# Altium schematic sheet AN-DGX - PMOD Connectors.SchDoc("AN-DGX - PMOD Connectors")
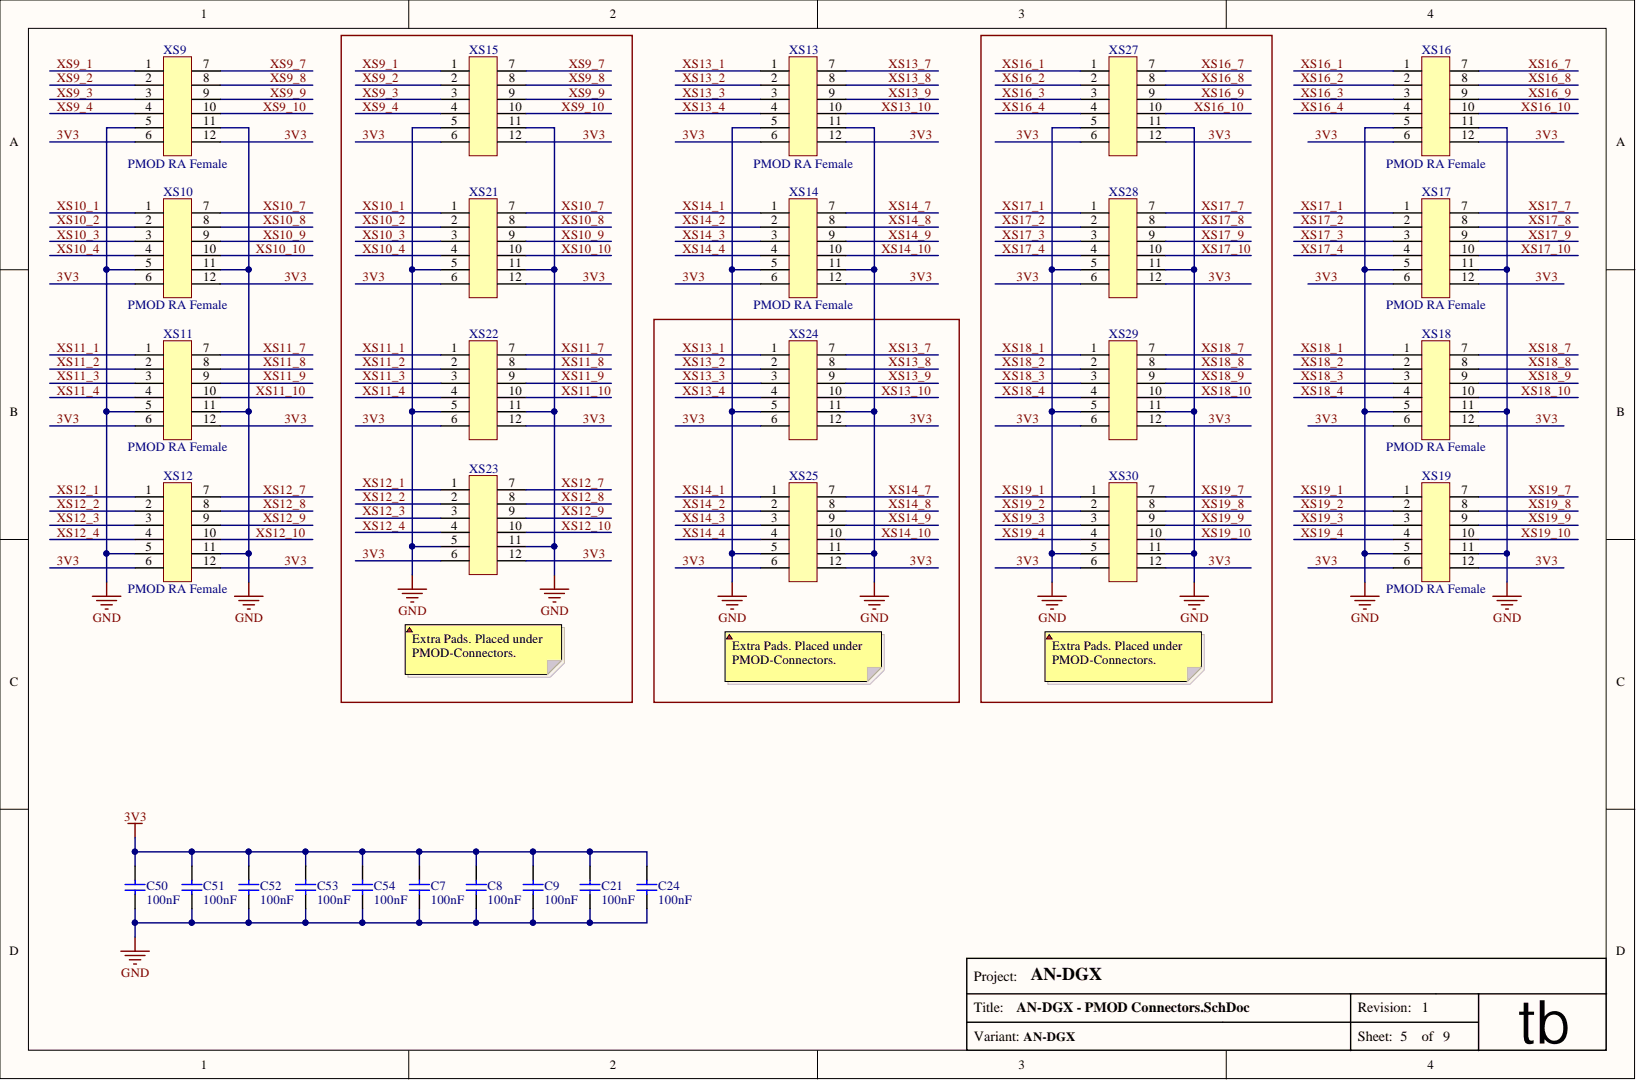
[diagram p0: AN-DGX - PMOD Connectors.SchDoc]

## Parts
C7  value 100nF  [C0603]  (hidden comment: CAP)
C8  value 100nF  [C0603]  (hidden comment: CAP)
C9  value 100nF  [C0603]  (hidden comment: CAP)
C21  value 100nF  [C0603]  (hidden comment: CAP)
C24  value 100nF  [C0603]  (hidden comment: CAP)
C50  value 100nF  [C0603]  (hidden comment: CAP)
C51  value 100nF  [C0603]  (hidden comment: CAP)
C52  value 100nF  [C0603]  (hidden comment: CAP)
C53  value 100nF  [C0603]  (hidden comment: CAP)
C54  value 100nF  [C0603]  (hidden comment: CAP)
XS9  value PMOD RA Female  [CONN_2DG_H_F]  (hidden comment: DG2_connector_horizontal_f)
XS10  value PMOD RA Female  [CONN_2DG_H_F]  (hidden comment: DG2_connector_horizontal_f)
XS11  value PMOD RA Female  [CONN_2DG_H_F]  (hidden comment: DG2_connector_horizontal_f)
XS12  value PMOD RA Female  [CONN_2DG_H_F]  (hidden comment: DG2_connector_horizontal_f)
XS13  value PMOD RA Female  [CONN_2DG_H_F]  (hidden comment: DG2_connector_horizontal_f)
XS14  value PMOD RA Female  [CONN_2DG_H_F]  (hidden comment: DG2_connector_horizontal_f)
XS15  Pads  [Conn_2DG_Pads]  (value not drawn)  (no BOM)
XS16  value PMOD RA Female  [CONN_2DG_H_F]  (hidden comment: DG2_connector_horizontal_f)
XS17  value PMOD RA Female  [CONN_2DG_H_F]  (hidden comment: DG2_connector_horizontal_f)
XS18  value PMOD RA Female  [CONN_2DG_H_F]  (hidden comment: DG2_connector_horizontal_f)
XS19  value PMOD RA Female  [CONN_2DG_H_F]  (hidden comment: DG2_connector_horizontal_f)
XS21  Pads  [Conn_2DG_Pads]  (value not drawn)  (no BOM)
XS22  Pads  [Conn_2DG_Pads]  (value not drawn)  (no BOM)
XS23  Pads  [Conn_2DG_Pads]  (value not drawn)  (no BOM)
XS24  Pads  [Conn_2DG_Pads]  (value not drawn)  (no BOM)
XS25  Pads  [Conn_2DG_Pads]  (value not drawn)  (no BOM)
XS27  Pads  [Conn_2DG_Pads]  (value not drawn)  (no BOM)
XS28  Pads  [Conn_2DG_Pads]  (value not drawn)  (no BOM)
XS29  Pads  [Conn_2DG_Pads]  (value not drawn)  (no BOM)
XS30  Pads  [Conn_2DG_Pads]  (value not drawn)  (no BOM)

## Nets
GND: C7.1 C8.1 C9.1 C21.1 C24.1 C50.1 C51.1 C52.1 C53.1 C54.1 XS9.5 XS9.11 XS10.5 XS10.11 XS11.5 XS11.11 XS12.5 XS12.11 XS13.5 XS13.11 XS14.5 XS14.11 XS15.5 XS15.11 XS16.5 XS16.11 XS17.5 XS17.11 XS18.5 XS18.11 XS19.5 XS19.11 XS21.5 XS21.11 XS22.5 XS22.11 XS23.5 XS23.11 XS24.5 XS24.11 XS25.5 XS25.11 XS27.5 XS27.11 XS28.5 XS28.11 XS29.5 XS29.11 XS30.5 XS30.11
3V3: C7.2 C8.2 C9.2 C21.2 C24.2 C50.2 C51.2 C52.2 C53.2 C54.2 XS9.6 XS9.12 XS10.6 XS10.12 XS11.6 XS11.12 XS12.6 XS12.12 XS13.6 XS13.12 XS14.6 XS14.12 XS15.6 XS15.12 XS16.6 XS16.12 XS17.6 XS17.12 XS18.6 XS18.12 XS19.6 XS19.12 XS21.6 XS21.12 XS22.6 XS22.12 XS23.6 XS23.12 XS24.6 XS24.12 XS25.6 XS25.12 XS27.6 XS27.12 XS28.6 XS28.12 XS29.6 XS29.12 XS30.6 XS30.12
XS9_1: XS9.1 XS15.1
XS9_2: XS9.2 XS15.2
XS9_3: XS9.3 XS15.3
XS9_4: XS9.4 XS15.4
XS9_7: XS9.7 XS15.7
XS9_8: XS9.8 XS15.8
XS9_9: XS9.9 XS15.9
XS9_10: XS9.10 XS15.10
XS10_1: XS10.1 XS21.1
XS10_2: XS10.2 XS21.2
XS10_3: XS10.3 XS21.3
XS10_4: XS10.4 XS21.4
XS10_7: XS10.7 XS21.7
XS10_8: XS10.8 XS21.8
XS10_9: XS10.9 XS21.9
XS10_10: XS10.10 XS21.10
XS11_1: XS11.1 XS22.1
XS11_2: XS11.2 XS22.2
XS11_3: XS11.3 XS22.3
XS11_4: XS11.4 XS22.4
XS11_7: XS11.7 XS22.7
XS11_8: XS11.8 XS22.8
XS11_9: XS11.9 XS22.9
XS11_10: XS11.10 XS22.10
XS12_1: XS12.1 XS23.1
XS12_2: XS12.2 XS23.2
XS12_3: XS12.3 XS23.3
XS12_4: XS12.4 XS23.4
XS12_7: XS12.7 XS23.7
XS12_8: XS12.8 XS23.8
XS12_9: XS12.9 XS23.9
XS12_10: XS12.10 XS23.10
XS13_1: XS13.1 XS24.1
XS13_2: XS13.2 XS24.2
XS13_3: XS13.3 XS24.3
XS13_4: XS13.4 XS24.4
XS13_7: XS13.7 XS24.7
XS13_8: XS13.8 XS24.8
XS13_9: XS13.9 XS24.9
XS13_10: XS13.10 XS24.10
XS14_1: XS14.1 XS25.1
XS14_2: XS14.2 XS25.2
XS14_3: XS14.3 XS25.3
XS14_4: XS14.4 XS25.4
XS14_7: XS14.7 XS25.7
XS14_8: XS14.8 XS25.8
XS14_9: XS14.9 XS25.9
XS14_10: XS14.10 XS25.10
XS16_1: XS16.1 XS27.1
XS16_2: XS16.2 XS27.2
XS16_3: XS16.3 XS27.3
XS16_4: XS16.4 XS27.4
XS16_7: XS16.7 XS27.7
XS16_8: XS16.8 XS27.8
XS16_9: XS16.9 XS27.9
XS16_10: XS16.10 XS27.10
XS17_1: XS17.1 XS28.1
XS17_2: XS17.2 XS28.2
XS17_3: XS17.3 XS28.3
XS17_4: XS17.4 XS28.4
XS17_7: XS17.7 XS28.7
XS17_8: XS17.8 XS28.8
XS17_9: XS17.9 XS28.9
XS17_10: XS17.10 XS28.10
XS18_1: XS18.1 XS29.1
XS18_2: XS18.2 XS29.2
XS18_3: XS18.3 XS29.3
XS18_4: XS18.4 XS29.4
XS18_7: XS18.7 XS29.7
XS18_8: XS18.8 XS29.8
XS18_9: XS18.9 XS29.9
XS18_10: XS18.10 XS29.10
XS19_1: XS19.1 XS30.1
XS19_2: XS19.2 XS30.2
XS19_3: XS19.3 XS30.3
XS19_4: XS19.4 XS30.4
XS19_7: XS19.7 XS30.7
XS19_8: XS19.8 XS30.8
XS19_9: XS19.9 XS30.9
XS19_10: XS19.10 XS30.10

## Library data of the parts
C7-C9 C21 C24 C50-C54 = CAP: Manufacturer Part: VJ0603Y104JXJPW1BC; Manufacturer: Vishay / Vitramon; Type of Component: Ceramic Capacitor; Supplier 3 Part: 001832; Supplier 3 Link: http://voron.ua/catalog/001832; Supplier 3: http://voron.ua; Supplier 2 Part: 182925; Supplier 2 Link: http://imrad.com.ua/ru/cc0603krx7r8bb104; Supplier 2: http://imrad.com.ua/; Supplier 1 Link: aliexpress.com/item/100pcs-lot-100nF-X7R-Error-10-50V-0603-smd-capacitor/32318089455.html?spm=2114.03010208.3.2.PDNk2A&ws_ab_test=searchweb201556_8,searchweb201602_1_10065_10068_112_10069_110_111_418_10017_109_108_10060_10061_10062_10057_10039_10056_10055_10054_10059_10058_10073_10070_10052_10053_10050_10051,searchweb201603_1&btsid=c39062ca-3db8-4562-8db0-c782461646c7; Supplier 1: aliexpress.com; Package / Case: 0603; Manufacturer Link: http://www.vishay.com/product?docid=28548; Component Kind: Standard; Library Name: RPI-VITA.IntLib
XS9-XS14 XS16-XS19 = DG2_connector_horizontal_f: SSHR-1-06-D; Type of Component: PMOD 2x6 Female RA; Supplier 2 Part: 019905; Supplier 2 Link: http://voron.ua/catalog/019905; Supplier 2: voron.ua; Supplier 1 Part: Header 2x6; Supplier 1 Link: aliexpress.com/item/100pcs-lot-2-54MM-2-6-P-2-54mm-2X6-Female-Double-Cab-Looper-Right-Angle/32674987997.html?spm=2114.03010208.3.2.JhMAJ9&ws_ab_test=searchweb0_0,searchweb201602_1_116_10065_117_10068_114_115_10069_113_10017_10080_10082_10081_10060_10061_10062_10039_10056_10055_10054_10059_10078_10079_10073_10070_421_420_10052_10053_10050_10051,searchweb201603_1&btsid=bf0396e8-448a-4b04-ba35-cc9eaa25fb0f; Supplier 1: aliexpress.com; Package / Case: Header 2x6 RA; Component Kind: Standard; Library Name: RPI-VITA.IntLib
XS15 XS21-XS25 XS27-XS30 = DG2_connector: Library Name: RPI-VITA.IntLib
